# Altium schematic sheet 温湿度三.SchDoc(温湿度三)
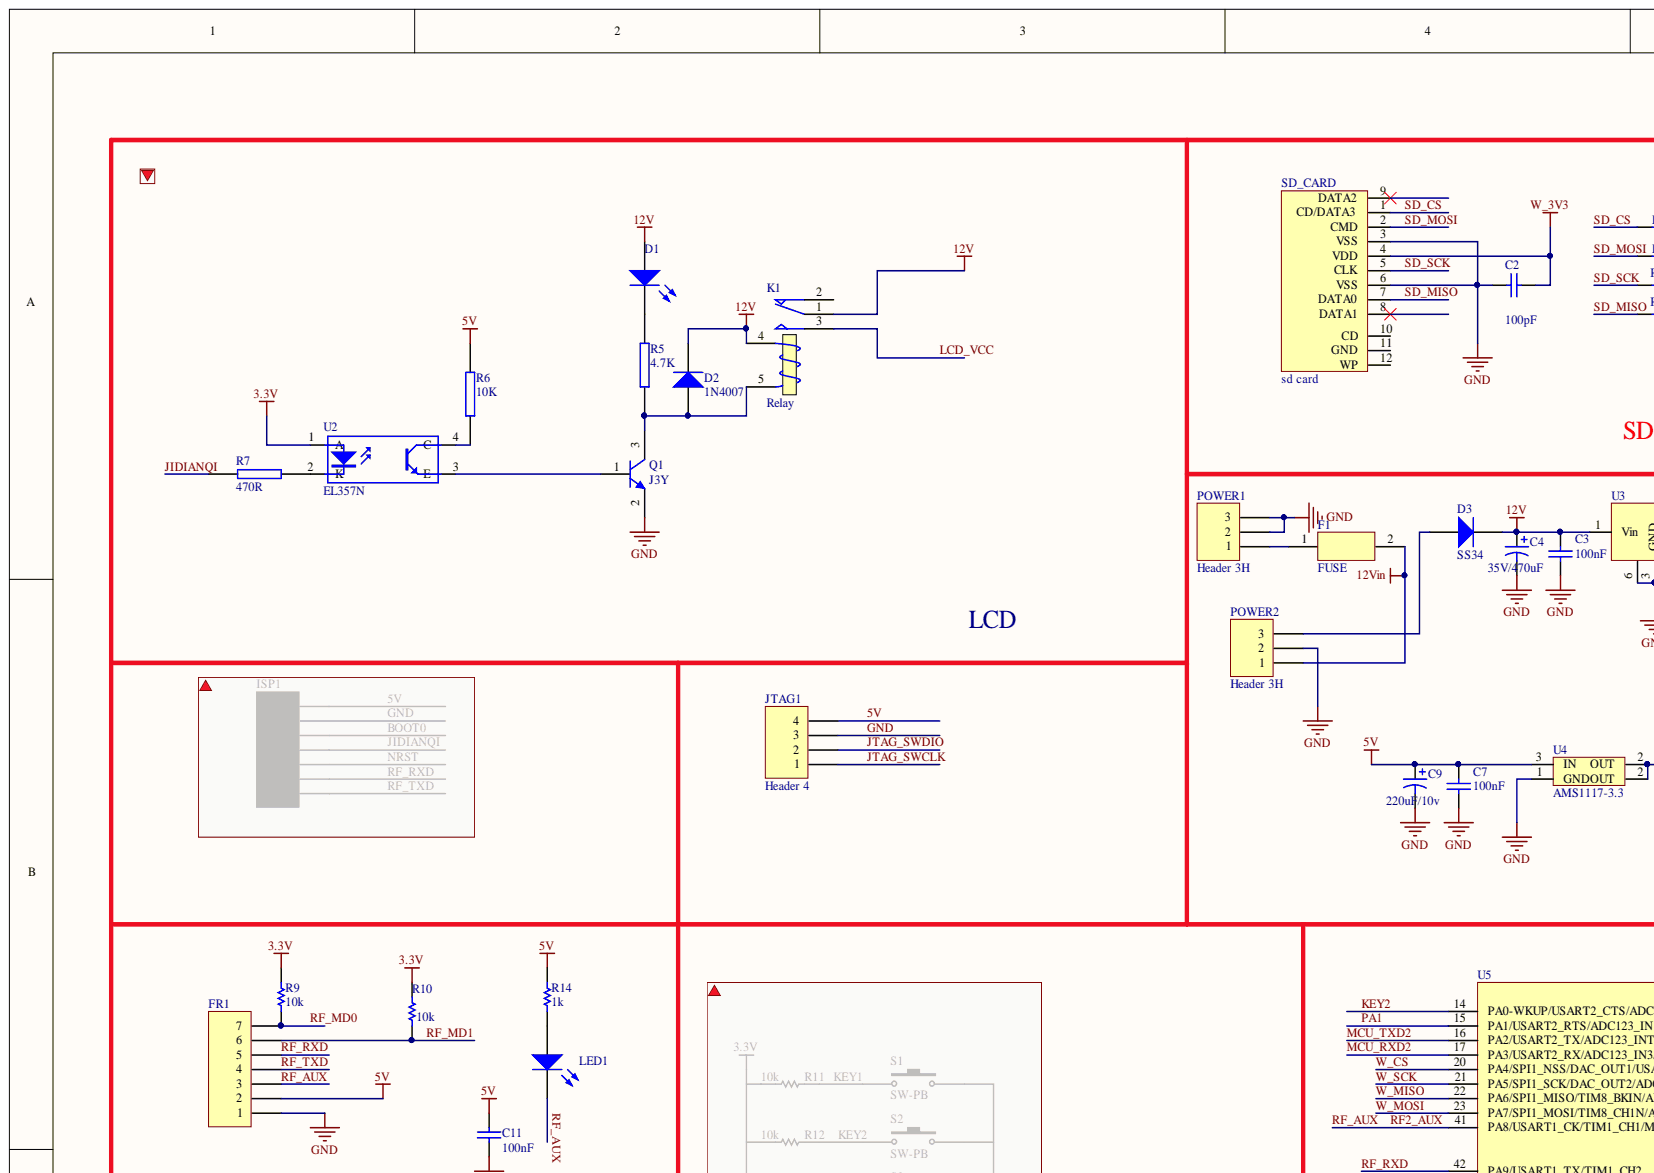
[diagram p0: 温湿度三.SchDoc, top left]
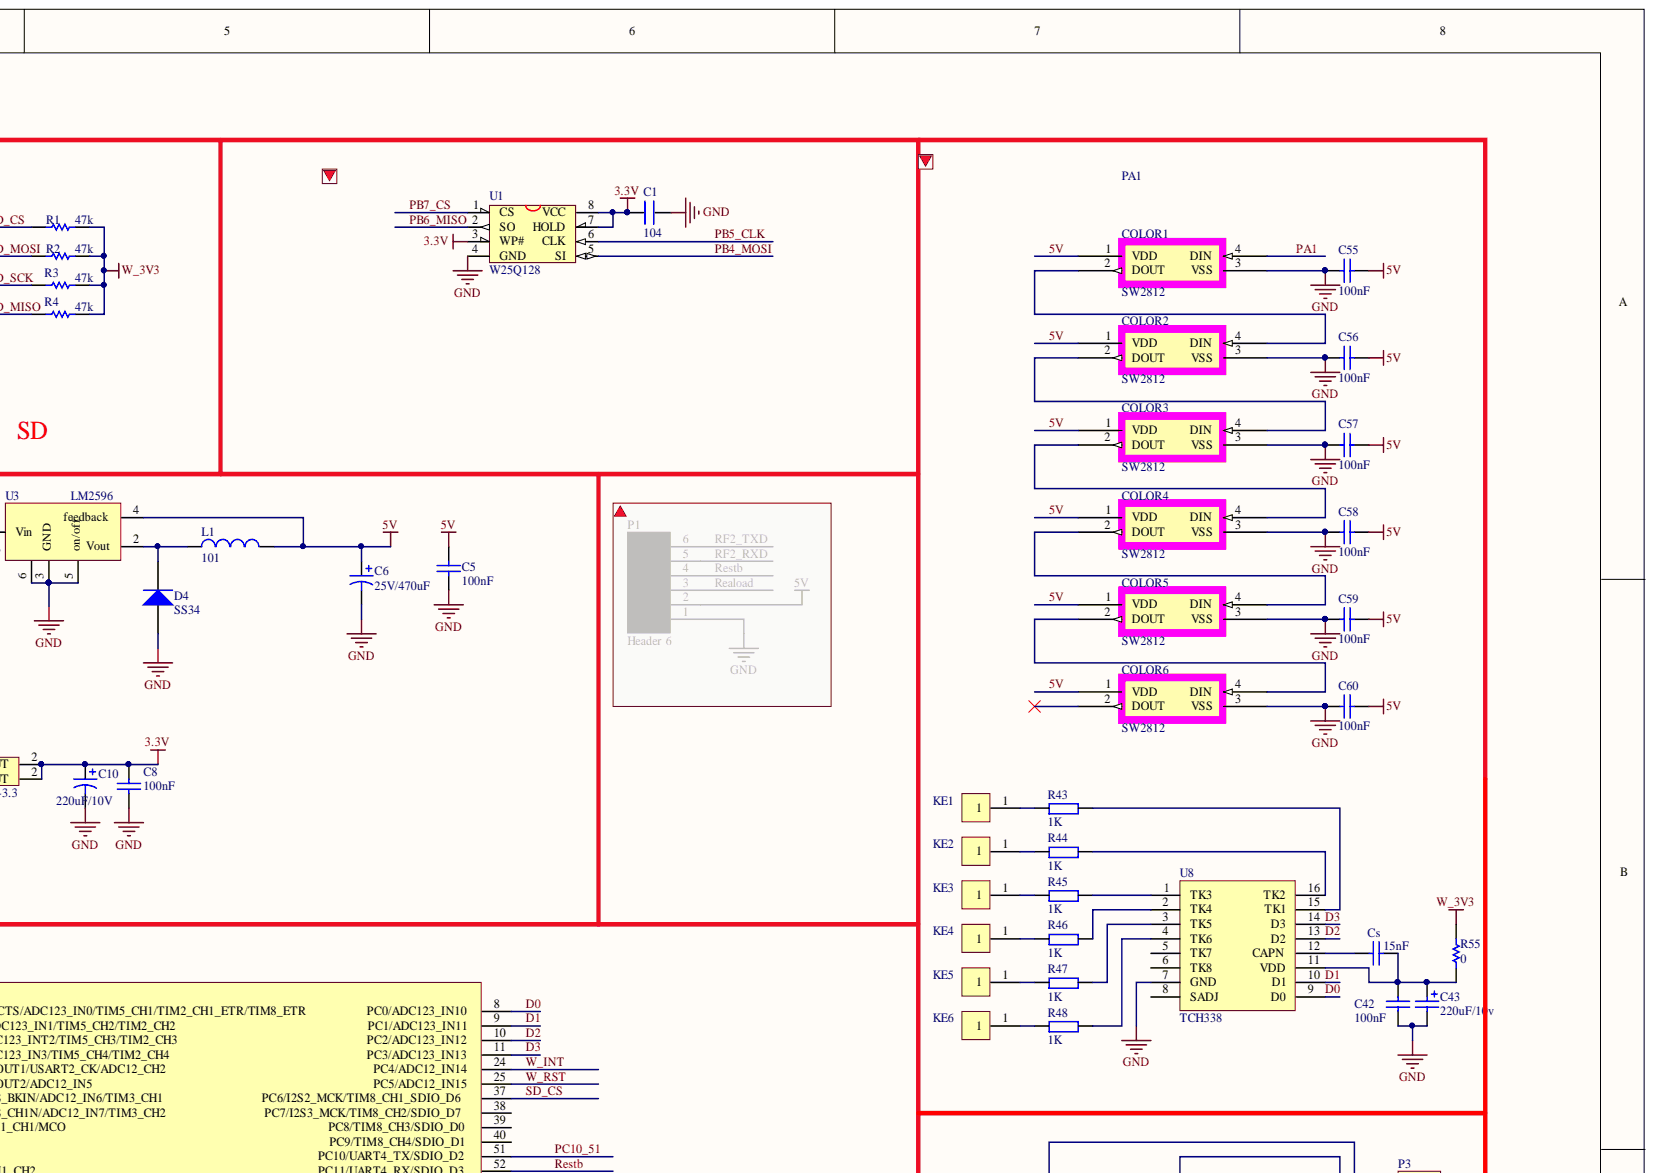
[diagram p1: 温湿度三.SchDoc, top right]
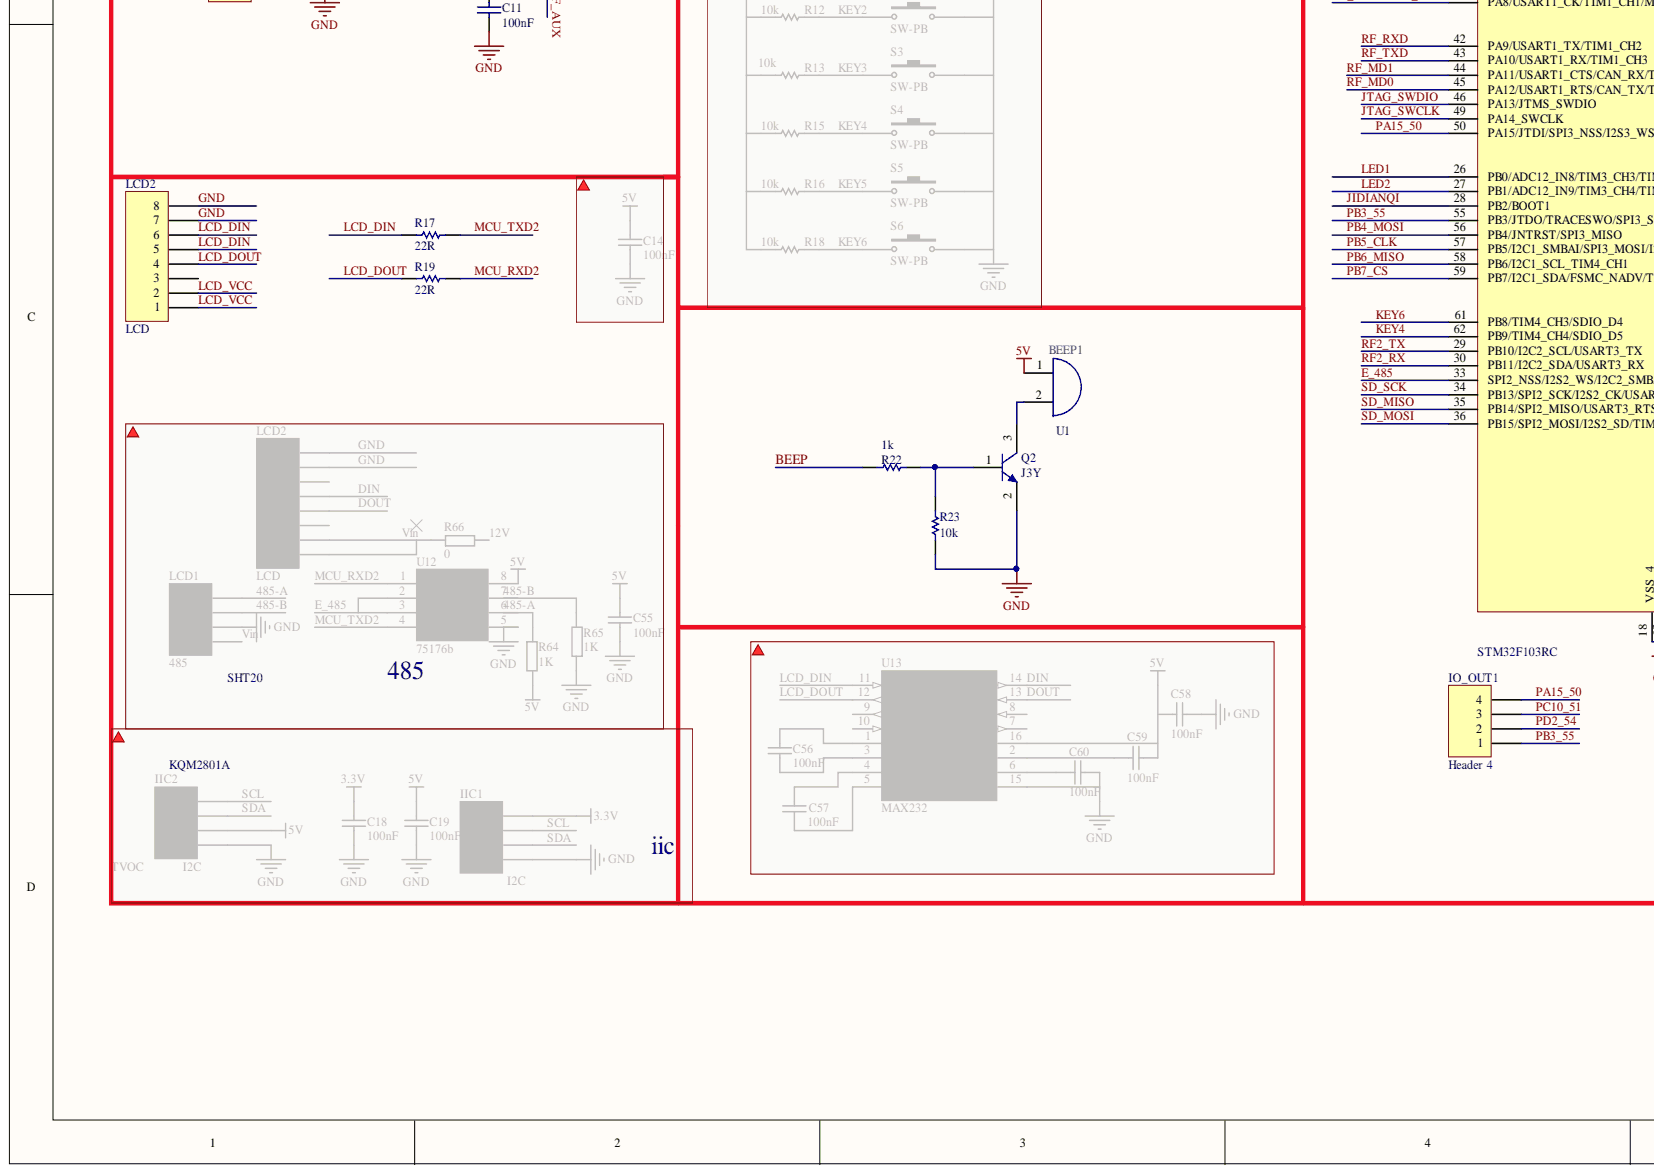
[diagram p2: 温湿度三.SchDoc, bottom left]
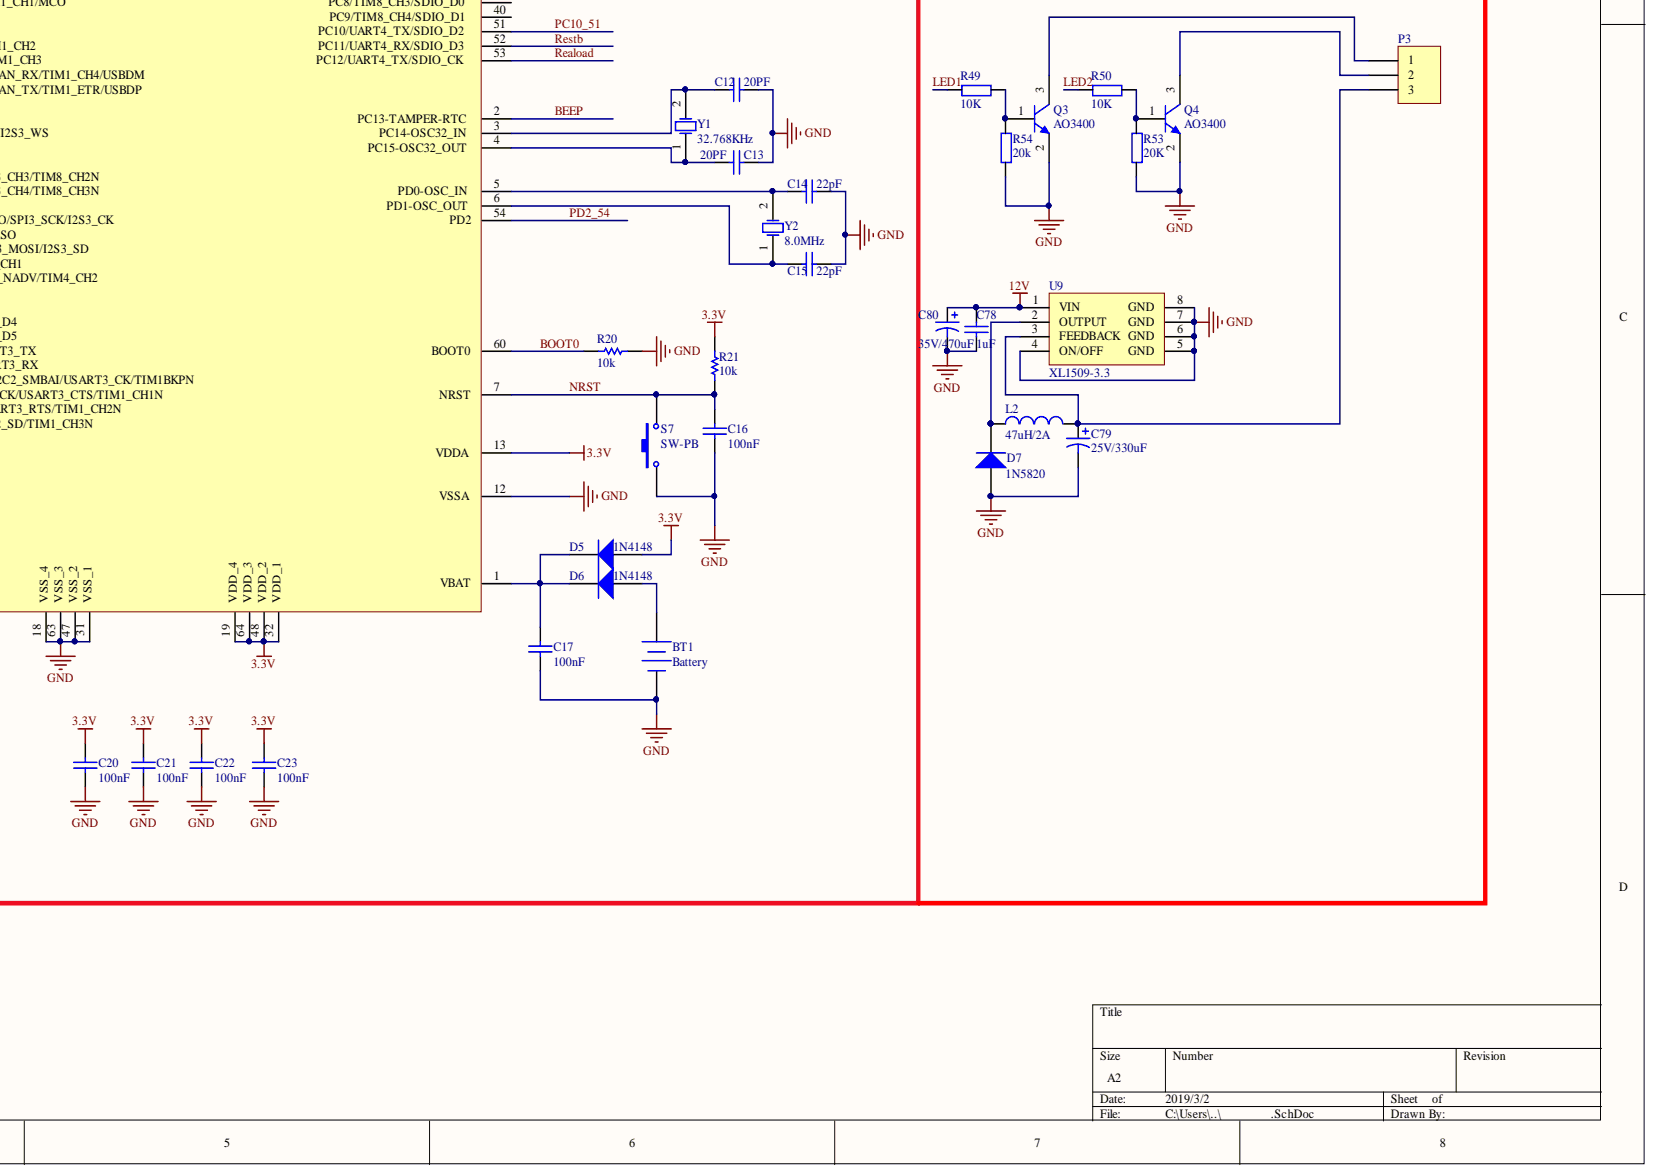
[diagram p3: 温湿度三.SchDoc, bottom right]

## Parts
BEEP1  U1  [BELL-5]
BT1  Battery  [BATM]
C1  104  [0805]
C2  value 100pF  [0805]  (hidden comment: 100nF)
C3  100nF  [0805]
C4  35V/470uF  [C10x12]
C5  100nF  [0805]
C6  25V/470uF  [C8X12]
C7  100nF  [0805]
C8  100nF  [0805]
C9  220uF/10v  [C5X8]
C10  220uF/10V  [C5X8]
C11  100nF  [0805]
C12  20PF  [0805]
C13  20PF  [0805]
C14  22pF  [0805]
C15  22pF  [0805]
C16  100nF  [0805]
C17  100nF  [0805]
C20  100nF  [0805]
C21  100nF  [0805]
C22  100nF  [0805]
C23  100nF  [0805]
C42  100nF  [C0805]
C43  220uF/10v  [C5X8]
C55  100nF  [C0603]
C56  100nF  [C0603]
C57  100nF  [C0603]
C58  100nF  [C0603]
C59  100nF  [C0603]
C60  100nF  [C0603]
C78  1uF  [0805]
C79  25V/330uF  [C8X12]
C80  35V/470uF  [C10x12]
COLOR1  SW2812  [sw6812-50x50]
COLOR2  SW2812  [sw6812-50x50]
COLOR3  SW2812  [sw6812-50x50]
COLOR4  SW2812  [sw6812-50x50]
COLOR5  SW2812  [sw6812-50x50]
COLOR6  SW2812  [sw6812-50x50]
Cs  15nF  [C0805]
D1  开关指示灯  [LED0805]  (value not drawn)
D2  1N4007  [DIODE_3*5.3]
D3  SS34  [DIODE_SMC]
D4  SS34  [DIODE_3*5.3]
D5  1N4148  [DIODE_SOP]
D6  1N4148  [DIODE_SOP]
D7  1N5820  [SMA]
F1  FUSE  [C1206]
FR1  无线模块接口  [HDR1X7]  (value not drawn)
IO_OUT1  Header 4  [HDR1X4]
JTAG1  Header 4  [HDR1X4]
K1  Relay  [JQC-3F]
KE1  Touch key-press  [按键弹簧]  (value not drawn)
KE2  Touch key-press  [按键弹簧]  (value not drawn)
KE3  Touch key-press  [按键弹簧]  (value not drawn)
KE4  Touch key-press  [按键弹簧]  (value not drawn)
KE5  Touch key-press  [按键弹簧]  (value not drawn)
KE6  Touch key-press  [按键弹簧]  (value not drawn)
L1  101  [L12*12*7]
L2  47uH/2A  [L7*7*4MM]
LCD2  LCD  [HY2.0-8PIN]
LED1  网络指示  [LED0805]  (value not drawn)
P3  灯带控制  [XH-3AW]  (value not drawn)
POWER1  Header 3H  [DC-6mm]
POWER2  Header 3H  [XH-3AW]
Q1  J3Y  [SOT-23]
Q2  J3Y  [SOT-23]
Q3  AO3400  [SOT-23]
Q4  AO3400  [SOT-23]
R1  47k  [R0805]
R2  47k  [R0805]
R3  47k  [R0805]
R4  47k  [R0805]
R5  4.7K  [R0805]
R6  10K  [R0805]
R7  470R  [R0805]
R9  10k  [R0805]
R10  10k  [R0805]
R14  1k  [R0805]
R17  22R  [R0805]
R19  22R  [R0805]
R20  10k  [R0805]
R21  10k  [R0805]
R22  1k  [R0805]
R23  10k  [R0805]
R43  1K  [0805]
R44  1K  [0805]
R45  1K  [0805]
R46  1K  [0805]
R47  1K  [0805]
R48  1K  [0805]
R49  10K  [0805]
R50  10K  [0805]
R53  20K  [0805]
R54  20k  [0805]
R55  0  [R0805]
S7  SW-PB  [3X6X2.5KEY-M]
SD_CARD  sd card  [SD_PUSH]
U1  W25Q128  [SOIC-8]
U2  EL357N  [SOP4]
U3  LM2596  [TO263-5]
U4  AMS1117-3.3  [SOT-223]
U5  STM32F103RC  [STM-LQFP64_M]
U8  TCH338  [SOP16]
U9  XL1509-3.3  [SOP8]
Y1  32.768KHz  [XTAL_3X8]
Y2  8.0MHz  [49S-DIP]

## Nets
3.3V: C1.1 C8.1 C10.1 C20.1 C21.1 C22.1 C23.1 D5.1 R9.2 R10.2 R21.1 U1.3(WP#) U1.7(HOLD) U1.8(VCC) U2.1(A) U4.2 U5.13(VDDA) U5.19(VDD_4) U5.32(VDD_1) U5.48(VDD_2) U5.64(VDD_3)
12V: C3.1 C4.1 C78.1 C80.1 D1.1 D2.2 D3.1 K1.1 K1.4 U3.1(Vin) U9.1(VIN)
12Vin: F1.2 POWER2.1
W_3V3: C2.2 R1.2 R2.2 R3.2 R4.2 R55.2 SD_CARD.4(VDD)
5V: BEEP1.1 C5.1 C6.1 C7.1 C9.1 C11.1 C55.2 C56.2 C57.2 C58.2 C59.2 C60.2 COLOR1.1(VDD) COLOR2.1(VDD) COLOR3.1(VDD) COLOR4.1(VDD) COLOR5.1(VDD) COLOR6.1(VDD) FR1.2 JTAG1.4 L1.2 R6.1 R14.2 U3.4(feedback) U4.3
BEEP: R22.2 U5.2(PC13-TAMPER-RTC)
BOOT0: R20.1 U5.60(BOOT0)
D0: U5.8(PC0/ADC123_IN10) U8.9(D0)
D1: U5.9(PC1/ADC123_IN11) U8.10(D1)
D2: U5.10(PC2/ADC123_IN12) U8.13(D2)
D3: U5.11(PC3/ADC123_IN13) U8.14(D3)
E_485: U5.33(SPI2_NSS/I2S2_WS/I2C2_SMBAI/USART3_CK/TIM1BKPN)
GND: BT1.2 C1.2 C2.1 C3.2 C4.2 C5.2 C6.2 C7.2 C8.2 C9.2 C10.2 C11.2 C12.2 C13.1 C14.2 C15.1 C16.2 C17.1 C20.2 C21.2 C22.2 C23.2 C42.1 C43.2 C55.1 C56.1 C57.1 C58.1 C59.1 C60.1 C78.2 C79.2 C80.2 COLOR1.3(VSS) COLOR2.3(VSS) COLOR3.3(VSS) COLOR4.3(VSS) COLOR5.3(VSS) COLOR6.3(VSS) D4.1 D7.1 FR1.1 JTAG1.3 LCD2.7 LCD2.8 POWER1.2 POWER1.3 POWER2.2 Q1.2 Q2.2 Q3.2 Q4.2 R20.2 R23.2 R53.1 R54.1 S7.1 SD_CARD.3(VSS) SD_CARD.6(VSS) U1.4(GND) U3.3(GND) U3.5(on/off) U3.6 U4.1 U5.12(VSSA) U5.18(VSS_4) U5.31(VSS_1) U5.47(VSS_2) U5.63(VSS_3) U8.7(GND) U9.4(ON/OFF) U9.5(GND) U9.6(GND) U9.7(GND) U9.8(GND)
JIDIANQI: R7.2 U5.28(PB2/BOOT1)
JTAG_SWCLK: JTAG1.1 U5.49(PA14_SWCLK)
JTAG_SWDIO: JTAG1.2 U5.46(PA13/JTMS_SWDIO)
KEY2: U5.14(PA0-WKUP/USART2_CTS/ADC123_IN0/TIM5_CH1/TIM2_CH1_ETR/TIM8_ETR)
KEY4: U5.62(PB9/TIM4_CH4/SDIO_D5)
KEY6: U5.61(PB8/TIM4_CH3/SDIO_D4)
LCD_DIN: LCD2.5 LCD2.6 R17.1
LCD_DOUT: LCD2.4 R19.1
LCD_VCC: K1.3 LCD2.1 LCD2.2
LED1: R49.1 U5.26(PB0/ADC12_IN8/TIM3_CH3/TIM8_CH2N)
LED2: R50.1 U5.27(PB1/ADC12_IN9/TIM3_CH4/TIM8_CH3N)
MCU_RXD2: R19.2 U5.17(PA3/USART2_RX/ADC123_IN3/TIM5_CH4/TIM2_CH4)
MCU_TXD2: R17.2 U5.16(PA2/USART2_TX/ADC123_INT2/TIM5_CH3/TIM2_CH3)
NRST: C16.1 R21.2 S7.2 U5.7(NRST)
PA1: COLOR1.4(DIN) U5.15(PA1/USART2_RTS/ADC123_IN1/TIM5_CH2/TIM2_CH2)
PA15_50: IO_OUT1.4 U5.50(PA15/JTDI/SPI3_NSS/I2S3_WS)
PB3_55: IO_OUT1.1 U5.55(PB3/JTDO/TRACESWO/SPI3_SCK/I2S3_CK)
PB4_MOSI: U1.5(SI) U5.56(PB4/JNTRST/SPI3_MISO)
PB5_CLK: U1.6(CLK) U5.57(PB5/I2C1_SMBAI/SPI3_MOSI/I2S3_SD)
PB6_MISO: U1.2(SO) U5.58(PB6/I2C1_SCL_TIM4_CH1)
PB7_CS: U1.1(CS) U5.59(PB7/I2C1_SDA/FSMC_NADV/TIM4_CH2)
PC10_51: IO_OUT1.3 U5.51(PC10/UART4_TX/SDIO_D2)
PD2_54: IO_OUT1.2 U5.54(PD2)
Reaload: U5.53(PC12/UART4_TX/SDIO_CK)
Restb: U5.52(PC11/UART4_RX/SDIO_D3)
RF2_AUX: FR1.3 LED1.2 U5.41(PA8/USART1_CK/TIM1_CH1/MCO)
RF2_RX: U5.30(PB11/I2C2_SDA/USART3_RX)
RF2_TX: U5.29(PB10/I2C2_SCL/USART3_TX)
RF_MD0: FR1.7 R9.1 U5.45(PA12/USART1_RTS/CAN_TX/TIM1_ETR/USBDP)
RF_MD1: FR1.6 R10.1 U5.44(PA11/USART1_CTS/CAN_RX/TIM1_CH4/USBDM)
RF_RXD: FR1.5 U5.42(PA9/USART1_TX/TIM1_CH2)
RF_TXD: FR1.4 U5.43(PA10/USART1_RX/TIM1_CH3)
SD_CS: R1.1 SD_CARD.1(CD/DATA3) U5.37(PC6/I2S2_MCK/TIM8_CH1_SDIO_D6)
SD_MISO: R4.1 SD_CARD.7(DATA0) U5.35(PB14/SPI2_MISO/USART3_RTS/TIM1_CH2N)
SD_MOSI: R2.1 SD_CARD.2(CMD) U5.36(PB15/SPI2_MOSI/I2S2_SD/TIM1_CH3N)
SD_SCK: R3.1 SD_CARD.5(CLK) U5.34(PB13/SPI2_SCK/I2S2_CK/USART3_CTS/TIM1_CH1N)
W_CS: U5.20(PA4/SPI1_NSS/DAC_OUT1/USART2_CK/ADC12_CH2)
W_INT: U5.24(PC4/ADC12_IN14)
W_MISO: U5.22(PA6/SPI1_MISO/TIM8_BKIN/ADC12_IN6/TIM3_CH1)
W_MOSI: U5.23(PA7/SPI1_MOSI/TIM8_CH1N/ADC12_IN7/TIM3_CH2)
W_RST: U5.25(PC5/ADC12_IN15)
W_SCK: U5.21(PA5/SPI1_SCK/DAC_OUT2/ADC12_IN5)
NetBEEP1_2: BEEP1.2 Q2.3
NetBT1_1: BT1.1 D6.1
NetC12_1: C12.1 U5.3(PC14-OSC32_IN) Y1.2
NetC13_2: C13.2 U5.4(PC15-OSC32_OUT) Y1.1
NetC14_1: C14.1 U5.5(PD0-OSC_IN) Y2.2
NetC15_2: C15.2 U5.6(PD1-OSC_OUT) Y2.1
NetC17_2: C17.2 D5.2 D6.2 U5.1(VBAT)
NetC42_2: C42.2 C43.1 Cs.2 R55.1 U8.11(VDD)
NetC79_1: C79.1 L2.2 P3.3 U9.3(FEEDBACK)
NetCOLOR1_2: COLOR1.2(DOUT) COLOR2.4(DIN)
NetCOLOR2_2: COLOR2.2(DOUT) COLOR3.4(DIN)
NetCOLOR3_2: COLOR3.2(DOUT) COLOR4.4(DIN)
NetCOLOR4_2: COLOR4.2(DOUT) COLOR5.4(DIN)
NetCOLOR5_2: COLOR5.2(DOUT) COLOR6.4(DIN)
NetCs_1: Cs.1 U8.12(CAPN)
NetD1_2: D1.2 R5.2
NetD2_1: D2.1 K1.5 Q1.3 R5.1
NetD3_2: D3.2 POWER2.3
NetD4_2: D4.2 L1.1 U3.2(Vout)
NetD7_2: D7.2 L2.1 U9.2(OUTPUT)
NetF1_1: F1.1 POWER1.1
NetKE1_1: KE1.1 R43.1
NetKE2_1: KE2.1 R44.1
NetKE3_1: KE3.1 R45.1
NetKE4_1: KE4.1 R46.1
NetKE5_1: KE5.1 R47.1
NetKE6_1: KE6.1 R48.1
NetLED1_1: LED1.1 R14.1
NetP3_1: P3.1 Q3.3
NetP3_2: P3.2 Q4.3
NetQ1_1: Q1.1 U2.3(E)
NetQ2_1: Q2.1 R22.1 R23.1
NetQ3_1: Q3.1 R49.2 R54.2
NetQ4_1: Q4.1 R50.2 R53.2
NetR6_2: R6.2 U2.4(C)
NetR7_1: R7.1 U2.2(K)
NetR43_2: R43.2 U8.15(TK1)
NetR44_2: R44.2 U8.16(TK2)
NetR45_2: R45.2 U8.1(TK3)
NetR46_2: R46.2 U8.2(TK4)
NetR47_2: R47.2 U8.3(TK5)
NetR48_2: R48.2 U8.4(TK6)
N00125:

Not connected: COLOR6 2(DOUT); K1 2; LCD2 3; SD_CARD 8(DATA1) 9(DATA2) 10(CD) 11(GND) 12(WP); U5 38(PC7/I2S3_MCK/TIM8_CH2/SDIO_D7) 39(PC8/TIM8_CH3/SDIO_D0) 40(PC9/TIM8_CH4/SDIO_D1); U8 5(TK7) 6(TK8) 8(SADJ)

## Library data of the parts
BEEP1 = BELL: 直径9mm; Component Kind: Standard
BT1 = Battery: Multicell Battery; Published: 24-Mar-1999; PackageReference: BAT-2; PackageDescription: Battery; 2 Leads; Library Name: Miscellaneous Devices.IntLib; LatestRevisionNote: Re-released for DXP Platform.; LatestRevisionDate: 17-Jul-2002; Component Kind: Standard; Publisher: Altium Limited
C1 = C: Library Name: Explorer STM32F407封装库.IntLib; Component Kind: Standard
C2 C3 C5 C7 C8 C11-C17 C20-C23 C42 C55-C60 = Cap: Capacitor; Simulation: CAP; Signal Integrity: Cap; Published: 8-Jun-2000; PackageReference: RAD-0.3; PackageDescription: Radial Cap, Thru-Hole; 2 Leads; 0.3 in Pin Spacing; LatestRevisionNote: Re-released for DXP Platform.; LatestRevisionDate: 17-Jul-2002; Component Kind: Standard; Publisher: Altium Limited
  C2: Library Name: PCB2D3D库0201.PcbLib
  C3 C5 C7 C8 C11 C16 C17 C20-C23: Value: 100nF; Library Name: PCB2D3D库0201.PcbLib
  C12: Value: 20PF; Library Name: PCB2D3D库0201.PcbLib
  C13: Value: 20pF; Library Name: PCB2D3D库0201.PcbLib
  C14 C15: Value: 22pF; Library Name: PCB2D3D库0201.PcbLib
  C42 C55-C60: Value: 100nF; Library Name: 温湿度第三版.SCHLIB
C4 C79 C80 = Cap Pol1: Polarized Capacitor (Radial); Value: 25V/470uF; Simulation: CAP; Signal Integrity: Cap Pol; Published: 8-Jun-2000; PackageReference: RB7.6-15; PackageDescription: Polarized Capacitor (Radial); 2 Leads; Library Name: Miscellaneous Devices.IntLib; LatestRevisionNote: Re-released for DXP Platform.; LatestRevisionDate: 17-Jul-2002; Component Kind: Standard; Publisher: Altium Limited
C6 C9 C10 = Cap Pol1: Polarized Capacitor (Radial); Simulation: CAP; Signal Integrity: Cap Pol; Published: 8-Jun-2000; PackageReference: RB7.6-15; PackageDescription: Polarized Capacitor (Radial); 2 Leads; Library Name: Miscellaneous Devices.IntLib; LatestRevisionNote: Re-released for DXP Platform.; LatestRevisionDate: 17-Jul-2002; Component Kind: Standard; Publisher: Altium Limited
  C6: Value: 25V/470uF
  C9: Value: 220uF/10v
  C10: Value: 220uF/10V
C43 = Cap Pol1_1: Polarized Capacitor (Radial); Value: 220uF/10v; Simulation: CAP; Signal Integrity: Cap Pol; Published: 8-Jun-2000; PackageReference: RB7.6-15; PackageDescription: Polarized Capacitor (Radial); 2 Leads; LatestRevisionNote: Re-released for DXP Platform.; LatestRevisionDate: 17-Jul-2002; Component Kind: Standard; Publisher: Altium Limited; Library Name: 温湿度第三版.SCHLIB
C78 Cs = Cap: Capacitor; Value: 100nF; Simulation: CAP; Signal Integrity: Cap; Published: 8-Jun-2000; PackageReference: RAD-0.3; PackageDescription: Radial Cap, Thru-Hole; 2 Leads; 0.3 in Pin Spacing; LatestRevisionNote: Re-released for DXP Platform.; LatestRevisionDate: 17-Jul-2002; Component Kind: Standard; Publisher: Altium Limited
  C78: Library Name: PCB2D3D库0201.PcbLib
  Cs: Library Name: 温湿度第三版.SCHLIB
D1 LED1 = LED2: Typical RED, GREEN, YELLOW, AMBER GaAs LED; Simulation: LED2; Published: 8-Jun-2000; PackageReference: 3.2X1.6X1.1; PackageDocument: Feb-2002; LatestRevisionNote: Re-released for DXP Platform.; LatestRevisionDate: 17-Jul-2002; Component Kind: Standard; Publisher: Altium Limited; Library Name: 战舰STM32开发板底板.IntLib
D2-D7 = Diode: Default Diode; Simulation: DIODE; Signal Integrity: Diode; Published: 8-Jun-2000; PackageVersion: Sep-1996; PackageReference: SMC; PackageDescription: DO-214-AB/SMC; 2 C-Bend Leads; Body 7.9 x 5.9 mm, inc. leads (LxW); Library Name: Miscellaneous Devices.IntLib; LatestRevisionNote: Re-released for DXP Platform.; LatestRevisionDate: 17-Jul-2002; Component Kind: Standard; Code_JEDEC: DO-214-AB; Publisher: Altium Limited
F1 = FUSE: 1206封装，2A自恢复保险丝; Component Kind: Standard; Library Name: 温湿度第三版.SCHLIB
FR1 = Header 7: Header, 7-Pin; Signal Integrity: Connector; Library Name: Miscellaneous Connectors.IntLib; LatestRevisionNote: Re-released for DXP Platform.; LatestRevisionDate: 17-Jul-2002; Component Kind: Standard; Publisher: Altium Limited
IO_OUT1 = Header 4_1: Header, 4-Pin; LatestRevisionNote: Re-released for DXP Platform.; LatestRevisionDate: 17-Jul-2002; Component Kind: Standard; Publisher: Altium Limited; Library Name: 温湿度第三版.SCHLIB
JTAG1 = Header 4: Header, 4-Pin; Library Name: Explorer STM32F407封装库.IntLib; LatestRevisionNote: Re-released for DXP Platform.; LatestRevisionDate: 17-Jul-2002; Component Kind: Standard; Publisher: Altium Limited
K1 = Relay: SPDT Relay; Simulation: SPDTRELAY; Published: 8-Jun-2000; PackageReference: MODULE5B; Library Name: Miscellaneous Devices.IntLib; LatestRevisionNote: Re-released for DXP Platform.; LatestRevisionDate: 17-Jul-2002; Component Kind: Standard; Publisher: Altium Limited
L1 = Inductor: Value: 100uH; Code_IEC: 1005; Simulation: INDUCTOR; Signal Integrity: Inductor; Published: 8-Jun-2000; PackageReference: 0402-A; PackageDescription: Chip Inductor; Library Name: Miscellaneous Devices.IntLib; LatestRevisionNote: IPC-7351 Footprint Added.; LatestRevisionDate: 29-May-2009; Description: Inductor; Component Kind: Standard; Code_JEITA: 0402; Publisher: Altium Limited
L2 = Inductor: 屏蔽电感/贴片功率电感; Value: 100uH; Code_IEC: 1005; Simulation: INDUCTOR; Signal Integrity: Inductor; Published: 8-Jun-2000; PackageReference: 0402-A; PackageDescription: Chip Inductor; Library Name: Miscellaneous Devices.IntLib; LatestRevisionNote: IPC-7351 Footprint Added.; LatestRevisionDate: 29-May-2009; Component Kind: Standard; Code_JEITA: 0402; Publisher: Altium Limited
LCD2 = Header 8: HY2.0mm,卧式贴片带锁扣接口-8pin; Signal Integrity: Connector; Library Name: Miscellaneous Connectors.IntLib; LatestRevisionNote: Re-released for DXP Platform.; LatestRevisionDate: 17-Jul-2002; Component Kind: Standard; Publisher: Altium Limited
P3 POWER1 POWER2 = Header 3H: Header, 3-Pin, Right Angle; Signal Integrity: Connector; LatestRevisionNote: Re-released for DXP Platform.; LatestRevisionDate: 17-Jul-2002; Component Kind: Standard; Publisher: Altium Limited
  POWER1 POWER2: Library Name: Miscellaneous Connectors.IntLib
  P3: Library Name: 温湿度第三版.SCHLIB
Q1-Q4 = 2N3904: NPN General Purpose Amplifier; Simulation: 2N3904; Signal Integrity: 2N3904; Published: 8-Jun-2000; PackageVersion: Sep-1998; PackageReference: TO-92A; PackageDescription: TO, Flat Index; 3 In-Line, Axial Leads; Body Dia. 4.5mm; Leads 0.51 x 0.48 mm (max); LatestRevisionNote: PCB Footprint 'BCY-W3/D4.7' replaced; LatestRevisionDate: 15-Jan-2003; DatasheetVersion: 1997; ComponentLink2URL: http://www.fairchildsemi.com/ds/2N%2F2N3904.pdf; ComponentLink2Description: Datasheet; ComponentLink1URL: http://www.fairchildsemi.com/; ComponentLink1Description: Manufacturer Link; Component Kind: Standard; Publisher: Altium Limited
  Q1 Q2: Library Name: Miscellaneous Devices.IntLib
  Q3 Q4: Library Name: 温湿度第三版.SCHLIB
R1-R4 = Res1: Resistor; Value: 47k; Simulation: RESISTOR; Signal Integrity: Res; Published: 8-Jun-2000; PackageReference: AXIAL-0.3; PackageDescription: Axial Device, Thru-Hole; 2 Leads; 0.3 in Pin Spacing; Library Name: Miscellaneous Devices.IntLib; LatestRevisionNote: Re-released for DXP Platform.; LatestRevisionDate: 17-Jul-2002; Component Kind: Standard; Publisher: Altium Limited
R5-R7 = RES2: Component Kind: Standard
R9 R10 R20-R23 = Res Semi: Semiconductor Resistor; Simulation: RES; Signal Integrity: Res Semi; Published: 8-Jun-2000; PackageReference: AXIAL-0.5; PackageDescription: Axial Device, Thru-Hole; 2 Leads; 0.5 in Pin Spacing; Library Name: Miscellaneous Devices.IntLib; LatestRevisionNote: Re-released for DXP Platform.; LatestRevisionDate: 17-Jul-2002; Component Kind: Standard; Publisher: Altium Limited
  R9 R10 R20 R21 R23: Value: 10k
  R22: Value: 1k
R14 = Res Semi: Semiconductor Resistor; Value: 10k; Simulation: RES; Signal Integrity: Res Semi; Published: 8-Jun-2000; PackageReference: AXIAL-0.5; PackageDescription: Axial Device, Thru-Hole; 2 Leads; 0.5 in Pin Spacing; Library Name: Miscellaneous Devices.IntLib; LatestRevisionNote: Re-released for DXP Platform.; LatestRevisionDate: 17-Jul-2002; Component Kind: Standard; Publisher: Altium Limited
R17 R19 = Res Semi: Semiconductor Resistor; Value: 1k; Simulation: RES; Signal Integrity: Res Semi; Published: 8-Jun-2000; PackageReference: AXIAL-0.5; PackageDescription: Axial Device, Thru-Hole; 2 Leads; 0.5 in Pin Spacing; Library Name: Miscellaneous Devices.IntLib; LatestRevisionNote: Re-released for DXP Platform.; LatestRevisionDate: 17-Jul-2002; Component Kind: Standard; Publisher: Altium Limited
R43-R50 R53 R54 = R: Component Kind: Standard; Library Name: 温湿度第三版.SCHLIB
R55 = Res Semi: Semiconductor Resistor; Value: 20R; Simulation: RES; Signal Integrity: Res Semi; Published: 8-Jun-2000; PackageReference: AXIAL-0.5; PackageDescription: Axial Device, Thru-Hole; 2 Leads; 0.5 in Pin Spacing; LatestRevisionNote: Re-released for DXP Platform.; LatestRevisionDate: 17-Jul-2002; Component Kind: Standard; Publisher: Altium Limited; Library Name: 温湿度第三版.SCHLIB
S7 = SW-PB: Switch; Published: 24-Mar-1999; PackageReference: SPST-2; PackageDescription: Switch; 2 Leads; Library Name: Miscellaneous Devices.IntLib; LatestRevisionNote: Re-released for DXP Platform.; LatestRevisionDate: 17-Jul-2002; Component Kind: Standard; Publisher: Altium Limited
U1 = W25X16: W25X16;SST25VF016;MX25L1605; Library Name: Explorer STM32F407封装库.IntLib; Component Kind: Standard
U2 = Optoisolator1: 4-Pin Phototransistor Optocoupler; Published: 24-Mar-1999; PackageReference: DIP-4; Library Name: Miscellaneous Devices.IntLib; LatestRevisionNote: Sch pins 3 & 4 Swapped.; LatestRevisionDate: 04-Mar-2005; DatasheetVersion: Nov-2003; Component Kind: Standard; Publisher: Altium Limited
U3 = Component_1: Library Name: LM2576.SchLib; Component Kind: Standard
U4 = AMS1117: Component Kind: Standard; Library Name: 战舰STM32开发板底板.IntLib
U5 = STM32F103R8: Library Name: STM32F103R8T6.SchLib; Component Kind: Standard
U9 = XL1509: Component Kind: Standard; Library Name: 温湿度第三版.SCHLIB
Y1 Y2 = XTAL: Crystal Oscillator; Simulation: XTAL; Published: 8-Jun-2000; LatestRevisionNote: Re-released for DXP Platform.; LatestRevisionDate: 17-Jul-2002; Component Kind: Standard; Publisher: Altium Limited; Library Name: 战舰STM32开发板底板.IntLib
COLOR1-COLOR6 = SW2812: Library Name: MySelf.SchLib; Component Kind: Standard
KE1-KE6 = Touch key-press: Component Kind: Standard; Library Name: 温湿度第三版.SCHLIB
SD_CARD = sd card: Component Kind: Standard; Library Name: 战舰STM32开发板底板.IntLib
U8 = TCH338: Component Kind: Standard; Library Name: 温湿度第三版.SCHLIB
